# Altium schematic sheet Ethernet interface.SchDoc
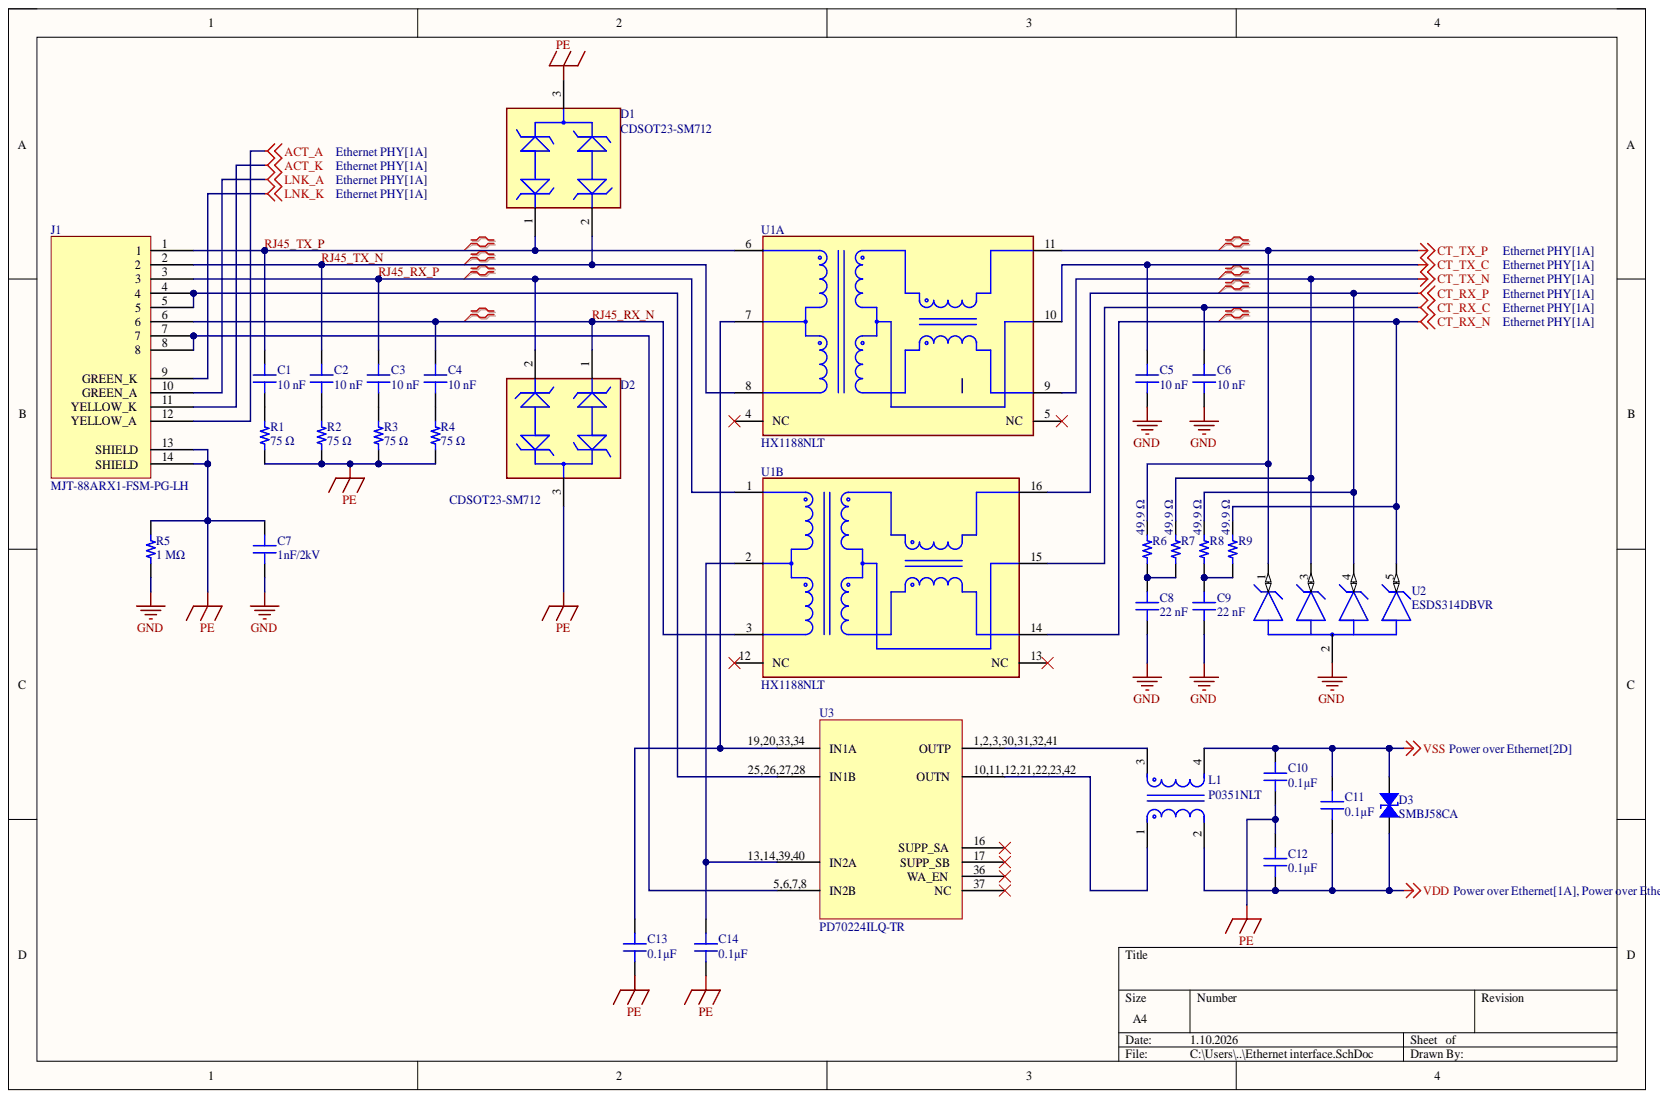
[diagram p0: Ethernet interface.SchDoc]

## Parts
C1  10000 pF  [CAP 0805_2012]  (value not drawn)
C2  Capacitance: 10 nF  [CAP 0805_2012]  (hidden comment: 10000 pF)
C3  Capacitance: 10 nF  [CAP 0805_2012]  (hidden comment: 10000 pF)
C4  Capacitance: 10 nF  [CAP 0805_2012]  (hidden comment: 10000 pF)
C5  10 nF  [CAP 0603_1608]
C6  10 nF  [CAP 0603_1608]
C7  1nF/2kV  [CAP 1206_3216 - 0.8MM]
C8  22 nF  [CAP 0603_1608]
C9  22 nF  [CAP 0603_1608]
C10  0.1µF  [CAP 0805_2012]
C11  0.1µF  [CAP 0805_2012]
C12  0.1µF  [CAP 0805_2012]
C13  0.1µF  [CAP 0805_2012]
C14  0.1µF  [CAP 0805_2012]
D1  CDSOT23-SM712  [FP-SOT23-MFG]
D2  CDSOT23-SM712  [FP-SOT23-MFG]
D3  SMBJ58CA  [DO214AA SMB]
J1  MJT-88ARX1-FSM-PG-LH  [MTJ88ARX1FSMPGLG]
L1  P0351NLT  [FP-P0351NLT-MFG]
R1  75 Ω  [RES 0805_2012]
R2  75 Ω  [RES 0805_2012]
R3  75 Ω  [RES 0805_2012]
R4  75 Ω  [RES 0805_2012]
R5  1 MΩ  [RES 0805_2012]
R6  49.9 Ω  [RES 0603_1608]
R7  49.9 Ω  [RES 0603_1608]
R8  49.9 Ω  [RES 0603_1608]
R9  49.9 Ω  [RES 0603_1608]
U1  HX1188NLT  [FP-HX1188NLT-MFG]  (parts A,B)
U2  ESDS314DBVR  [FP-DBV0005A-IPC_A]
U3  PD70224ILQ-TR  [PD70224ILQTR]

## Nets
ACT_A: J1.12(YELLOW_A)
ACT_K: J1.11(YELLOW_K)
CT_RX_C: C6.2 U1.15
CT_RX_N: R9.2 U1.14 U2.5
CT_RX_P: R8.2 U1.16 U2.4
CT_TX_C: C5.2 U1.10
CT_TX_N: R7.2 U1.9 U2.3
CT_TX_P: R6.2 U1.11 U2.1
GND: C5.1 C6.1 C7.1 C8.1 C9.1 R5.1 U2.2
LNK_A: J1.10(GREEN_A)
LNK_K: J1.9(GREEN_K)
PE: C7.2 C10.1 C12.2 C13.1 C14.1 D1.3 D2.3 J1.13(SHIELD) J1.14(SHIELD) R1.1 R2.1 R3.1 R4.1 R5.2
VDD: C11.1 C12.1 D3.1 L1.2
VSS: C10.2 C11.2 D3.2 L1.4
RJ45_RX_N: C4.2 D2.1 J1.6 U1.3
RJ45_RX_P: C3.2 D2.2 J1.3 U1.1
RJ45_TX_N: C2.2 D1.2 J1.2 U1.8
RJ45_TX_P: C1.2 D1.1 J1.1 U1.6
NetC1_1: C1.1 R1.2
NetC2_1: C2.1 R2.2
NetC3_1: C3.1 R3.2
NetC4_1: C4.1 R4.2
NetC8_2: C8.2 R6.1 R7.1
NetC9_2: C9.2 R8.1 R9.1
NetC13_2: C13.2 U1.7 U3.19,20,33,34(IN1A)
NetC14_2: C14.2 U1.2 U3.13,14,39,40(IN2A)
NetJ1_4: J1.4 J1.5 U3.25,26,27,28(IN1B)
NetJ1_7: J1.7 J1.8 U3.5,6,7,8(IN2B)
NetL1_1: L1.1 U3.10,11,12,21,22,23,42(OUTN)
NetL1_3: L1.3 U3.1,2,3,30,31,32,41(OUTP)

Not connected: U1 4(NC) 5(NC) 12(NC) 13(NC); U3 16(SUPP_SA) 17(SUPP_SB) 36(WA_EN) 37(NC)

## Library data of the parts
C1 = GRM2165C2A103JA01D: CAP CER 10000PF 100V C0GNP0 0805; manufacturer: Murata Electronics; Capacitance: 10 nF; Database Table Name: Capacitors - Ceramic - 0805; Temperature Coefficient: C0G, NP0; Tolerance: ±5%; Applications: General Purpose; Component Kind: Standard; componentlink1description: Datasheet; componentlink1url: https://search.murata.co.jp/Ceramy/image/img/A01X/G101/ENG/GRM2165C2A103JA01-01A.pdf; componentlink2description: DigiKey Link; componentlink2url: https://www.digikey.com/en/products/detail/murata-electronics/GRM2165C2A103JA01D/13904864; Device Package: 0805 (2012 Metric); lastupdated: 9.02.2024 20:14:44; Library Name: Celestial Altium Library - altium_library.DbLib; Lifecycle Status: Active; Minimum Order: 1; Mounting Type: Surface Mount, MLCC; Operating Temperature: -55°C ~ 125°C; Package / Case: 0805 (2012 Metric); packaging: TapeAndReel; Part Number: GRM2165C2A103JA01D; Part Status: Active; partid: 48723; price: 0.02; series: GRM; Size / Dimension: 0.079" L x 0.049" W (2.00mm x 1.25mm); Supplier 1: DigiKey; Supplier Part Number 1: 490-GRM2165C2A103JA01DCT-ND; Thickness (Max): 0.026" (0.65mm); value: 10000 pF; Voltage - Rated: 100V
C2-C4 = GRM2165C2A103JA01D: CAP CER 10000PF 100V C0GNP0 0805; manufacturer: Murata Electronics; Database Table Name: Capacitors - Ceramic - 0805; Temperature Coefficient: C0G, NP0; Tolerance: ±5%; Applications: General Purpose; Component Kind: Standard; componentlink1description: Datasheet; componentlink1url: https://search.murata.co.jp/Ceramy/image/img/A01X/G101/ENG/GRM2165C2A103JA01-01A.pdf; componentlink2description: DigiKey Link; componentlink2url: https://www.digikey.com/en/products/detail/murata-electronics/GRM2165C2A103JA01D/13904864; Device Package: 0805 (2012 Metric); lastupdated: 9.02.2024 20:14:44; Library Name: Celestial Altium Library - altium_library.DbLib; Lifecycle Status: Active; Minimum Order: 1; Mounting Type: Surface Mount, MLCC; Operating Temperature: -55°C ~ 125°C; Package / Case: 0805 (2012 Metric); packaging: TapeAndReel; Part Number: GRM2165C2A103JA01D; Part Status: Active; partid: 48723; price: 0.02; series: GRM; Size / Dimension: 0.079" L x 0.049" W (2.00mm x 1.25mm); Supplier 1: DigiKey; Supplier Part Number 1: 490-GRM2165C2A103JA01DCT-ND; Thickness (Max): 0.026" (0.65mm); value: 10000 pF; Voltage - Rated: 100V
C5 C6 = 0603B103K500CT: CAP CER 10000PF 50V X7R 0603; Database Table Name: Capacitors - Ceramic - 0603; Capacitance: 10 nF; Component Kind: Standard; Library Name: Celestial Altium Library.DbLib; Part Number: 0603B103K500CT
C7 = 1206B102K202NT: CAP CER 1000PF 2KV X7R 1206; Database Table Name: Capacitors - Ceramic - 1206; Capacitance: 1000 pF; Component Kind: Standard; Library Name: Celestial Altium Library.DbLib; Part Number: 1206B102K202NT; Supplier 1: Arrow Electronics; Supplier Part Number 1: 1206B102K202NT
C8 C9 = 0603B223K500CT: CAP CER 0.022UF 50V X7R 0603; Database Table Name: Capacitors - Ceramic - 0603; Capacitance: 22 nF; Component Kind: Standard; Library Name: Celestial Altium Library.DbLib; Part Number: 0603B223K500CT
C10-C14 = 08051C104KAT2A: CAP CER 0.1UF 100V X7R 0805; Database Table Name: Capacitors - Ceramic - 0805; Capacitance: 0.1µF; Component Kind: Standard; Library Name: Celestial Altium Library.DbLib; Part Number: 08051C104KAT2A
D1 D2 = CMP-2000-05081-2: TVS DIODE 7V/12V 14V/26V SOT23-3; Manufacturer: Bourns Inc.; Breakdown Voltage: 7.5V; Capacitance: 75pF; Clamping Voltage: 26V; Direction: Bidirectional; Number Of Channels: 2; Peak Pulse Current: 17A; Peak Pulse Power: 400W; Reverse Standoff Voltage: 12V; Standoff Height: 0.05mm; Value: 75pF; Automotive: No; Category: Diode; Component Kind: Standard; ComponentLink2Description: Datasheet; ComponentLink2URL: https://www.bourns.com/docs/Product-Datasheets/CDSOT23-SM712.pdf; Device Class L1: Circuit Protection; Device Class L2: TVS Diodes; Device Class L3: unset; Digikey Description: TVS DIODE 7V/12V 14V/26V SOT23-3; Height: 1.17mm; Ipc Land Pattern Name: SOT95P229X103-3; Lead Free: Yes; Library Name: Altium Content Vault; Manufacturer Part Number: CDSOT23-SM712; Mouser Description: Transient Voltage Suppressor, TVS, CDSOT23 Series, Unidirectional, 12 V, SOT-23, 3, 13.3 V; Octopart: https://octopart.com/cdsot23-sm712-bourns-7576327; Package: SOT23; RoHS: TRUE; Temperature: -55°C to +150°C; Temperature Range High: +150°C; Temperature Range Low: -55°C
D3 = SMBJ58CA: TVS DIODE 58VWM 93.6VC DO214AA; Database Table Name: Diodes - TVS; Component Kind: Standard; Library Name: Celestial Altium Library.DbLib; Part Number: SMBJ58CA
J1 = MTJ-88ARX1-FSM-PG-LH: Component Kind: Standard; Library Name: SchLib.SchLib; Supplier 1: TME; Supplier Part Number 1: MTJ88ARX1FSMPGLH
L1 = CMP-16296-000003-1: COMMON MODE CHOKE 2.8A 2LN SMD; DC Resistance (DCR): 80mR; Inductance: 1.47mH; Number of Lines: 2; Shielding: Unshielded; Tolerance: 35%; Case/Package: SMD/SMT; Component Kind: Standard; Current: 2.8A; DC Current: 2.8A; Depth: 17.02mm; Height: 9.91mm; Length: 19.56mm; Library Name: Altium Content Vault; Max DC Current: 2.8A; Max Operating Temperature: 120°C; Military Standard: Not; Min Operating Temperature: -40°C; Mount: Surface Mount; Number of Pins: 50; Package Quantity: 1; Packaging: Tape and Reel; Radiation Hardening: No; REACH SVHC: No SVHC; Series: -; Termination: SMD/SMT; Width: 0.67inch
R1-R4 = CRCW080575R0FKEA: RES SMD 75 OHM 1% 1/8W 0805; Manufacturer: Vishay Dale; Database Table Name: Resistors - Surface Mount - 0805; Power (Watts): 0.125W, 1/8W; Resistance: 75 Ohms; Temperature Coefficient: ±100ppm/°C; Component Kind: Standard; ComponentLink1Description: Datasheet; ComponentLink1URL: http://www.vishay.com/docs/20035/dcrcwe3.pdf; ComponentLink2Description: DigiKey Link; ComponentLink2URL: https://www.digikey.com/en/products/detail/vishay-dale/CRCW080575R0FKEA/1175509; Composition: Thick Film; Device Package: 0805 (2012 Metric); Features: Automotive AEC-Q200; Height - Seated (Max): 0.020" (0.50mm); LastUpdated: 8.02.2024 21:02:17; Library Name: Celestial Altium Library - altium_library.DbLib; Lifecycle Status: Active; Minimum Order: 1; Number of Terminations: 2; Operating Temperature: -55°C ~ 155°C; Package / Case: 0805 (2012 Metric); Packaging: TapeAndReel; Part Number: CRCW080575R0FKEA; Part Status: Active; PartId: 32714; Price: 0.01; Ratings: AEC-Q200; Series: CRCW; Size / Dimension: 0.079" L x 0.049" W (2.00mm x 1.25mm); Supplier 1: DigiKey; Supplier Device Package: 0805; Supplier Part Number 1: 541-75.0CCT-ND; Tolerance: ±1%; Value: 75 Ω
R5 = RCS08051M00FKEA: RES SMD 1M OHM 1% 1/2W 0805; Manufacturer: Vishay Dale; Database Table Name: Resistors - Surface Mount - 0805; Power (Watts): 0.5W, 1/2W; Temperature Coefficient: ±100ppm/°C; Component Kind: Standard; ComponentLink1Description: Datasheet; ComponentLink1URL: http://www.vishay.com/docs/20065/rcse3.pdf; ComponentLink2Description: DigiKey Link; ComponentLink2URL: https://www.digikey.com/en/products/detail/vishay-dale/RCS08051M00FKEA/5866999; Composition: Thick Film; Device Package: 0805 (2012 Metric); Features: Automotive AEC-Q200, Pulse Withstanding; Height - Seated (Max): 0.020" (0.50mm); LastUpdated: 8.02.2024 21:02:38; Library Name: Celestial Altium Library - altium_library.DbLib; Lifecycle Status: Active; Minimum Order: 1; Number of Terminations: 2; Operating Temperature: -55°C ~ 155°C; Package / Case: 0805 (2012 Metric); Packaging: TapeAndReel; Part Number: RCS08051M00FKEA; Part Status: Active; PartId: 27791; Price: 0.02; Ratings: AEC-Q200; Resistance: 1 MΩ; Series: RCS e3; Size / Dimension: 0.079" L x 0.049" W (2.00mm x 1.25mm); Supplier 1: DigiKey; Supplier Device Package: 0805; Supplier Part Number 1: 541-2853-1-ND; Tolerance: ±1%; Value: 1 MΩ
R6-R9 = TNPW060349R9BYEN: RES 49.9 OHM 0.1% 1/10W 0603; Database Table Name: Resistors - Surface Mount - 0603; Component Kind: Standard; Library Name: Celestial Altium Library.DbLib; Part Number: TNPW060349R9BYEN; Value: 49.9 Ω
U1 = CMP-2000-06432-2: XFRMR MAGNETIC 1PORT 1:1 10/100; DC Resistance (DCR): 1.5R; Insertion Loss: -3dB; Isolation Voltage: 1.5kV; Lead/Base Style: Gull Wing; Return Loss: -12dB; Shielding: Unshielded; Component Kind: Standard; Depth: 9.65mm; Height: 5.97mm; Height - Seated (Max): 6.22mm; Length: 12.7mm; Library Name: Altium Content Vault; Max Operating Temperature: 85°C; Min Operating Temperature: -40°C; Mount: Surface Mount; Number of Pins: 16; Package Quantity: 1; Packaging: Tape and Reel; Radiation Hardening: No; RoHS Compliant: Yes; Series: -; Termination: SMD/SMT; Turns Ratio: 1:1; Width: 7.11mm
U2 = CMP-04985-000014-1: FAMILY OF UNI-DIRECTIONAL SURGE; Clamping Voltage: 6.5V; Interface: USB; Min Breakdown Voltage: 4.5V; Number of Channels: 4; Peak Pulse Current: 25A; Peak Pulse Power: 170W; Power Line Protection: No; Reverse Standoff Voltage: 3.6V; Case/Package: SOT-23-5; Component Kind: Standard; Library Name: Altium Content Vault; Max Operating Temperature: 125°C; Min Operating Temperature: -40°C; Mount: Surface Mount; Number of Unidirectional Channels: 4; Packaging: Cut Tape; Resistor Type: Zener; RoHS Compliant: Yes
U3 = PD70224ILQ-TR: Component Kind: Standard; Library Name: SchLib.SchLib; Supplier 1: TME; Supplier Part Number 1: PD70224ILQ-TR
